# Altium schematic sheet TopSheet.SchDoc
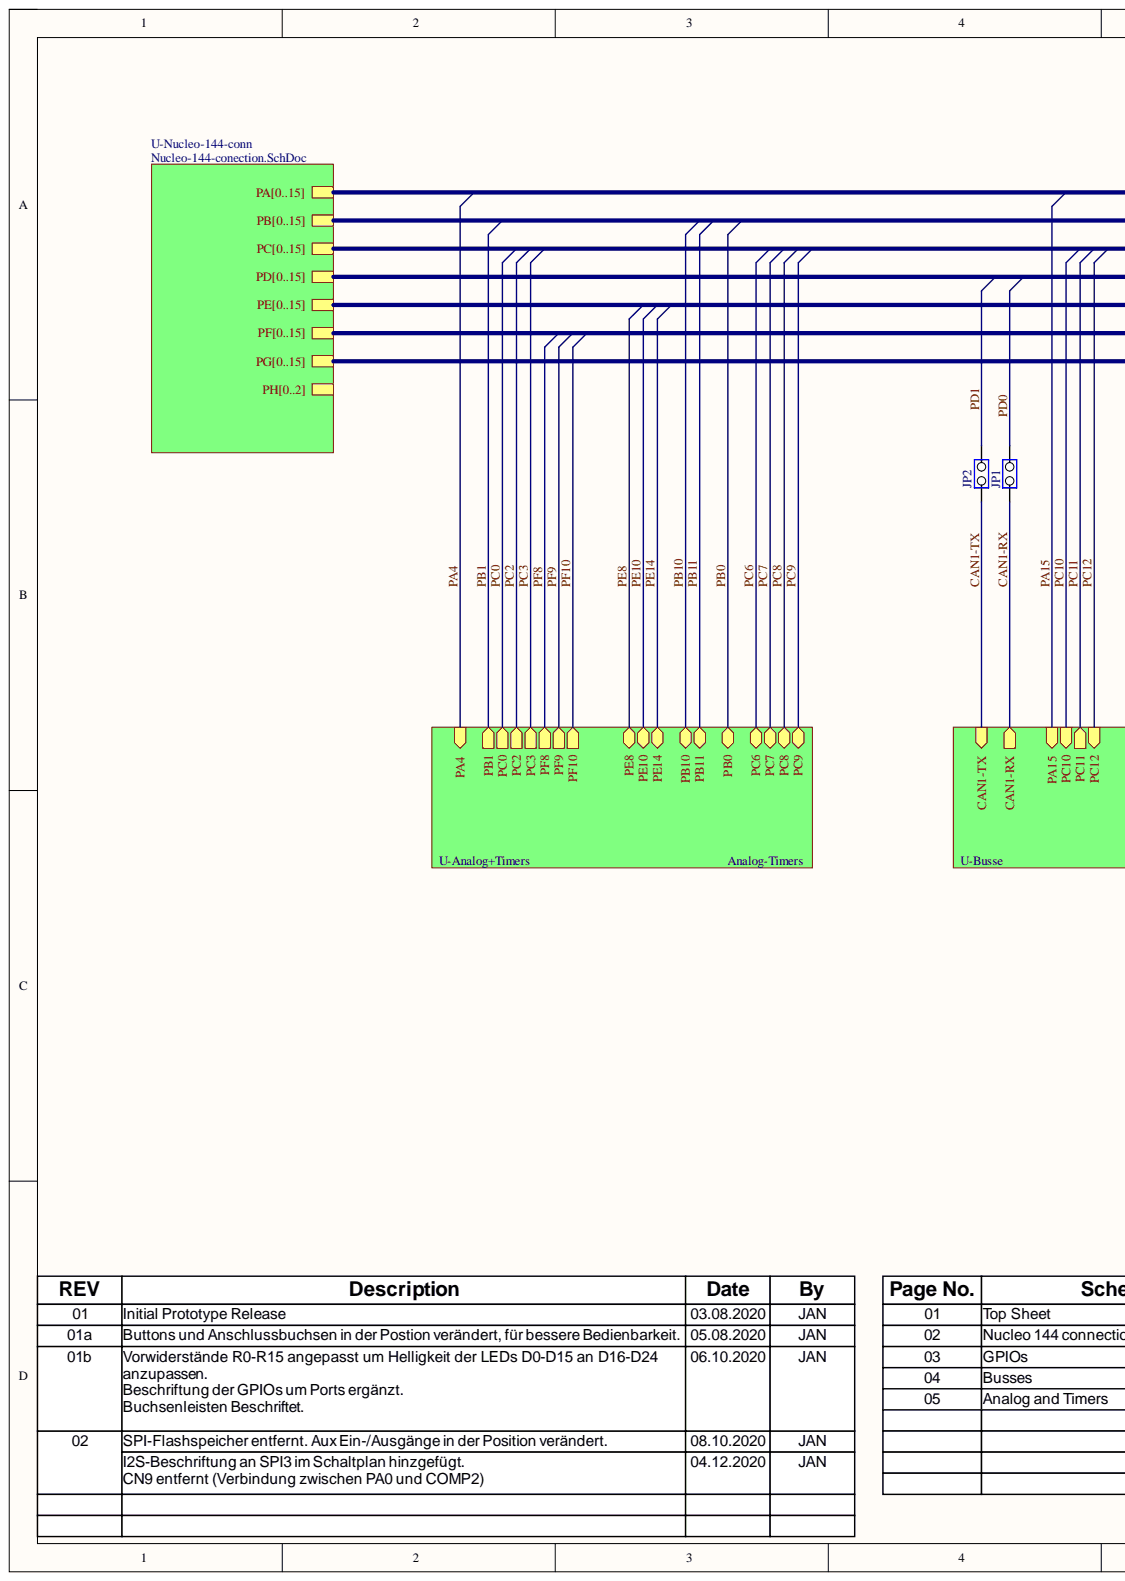
[diagram p0: TopSheet.SchDoc, left]
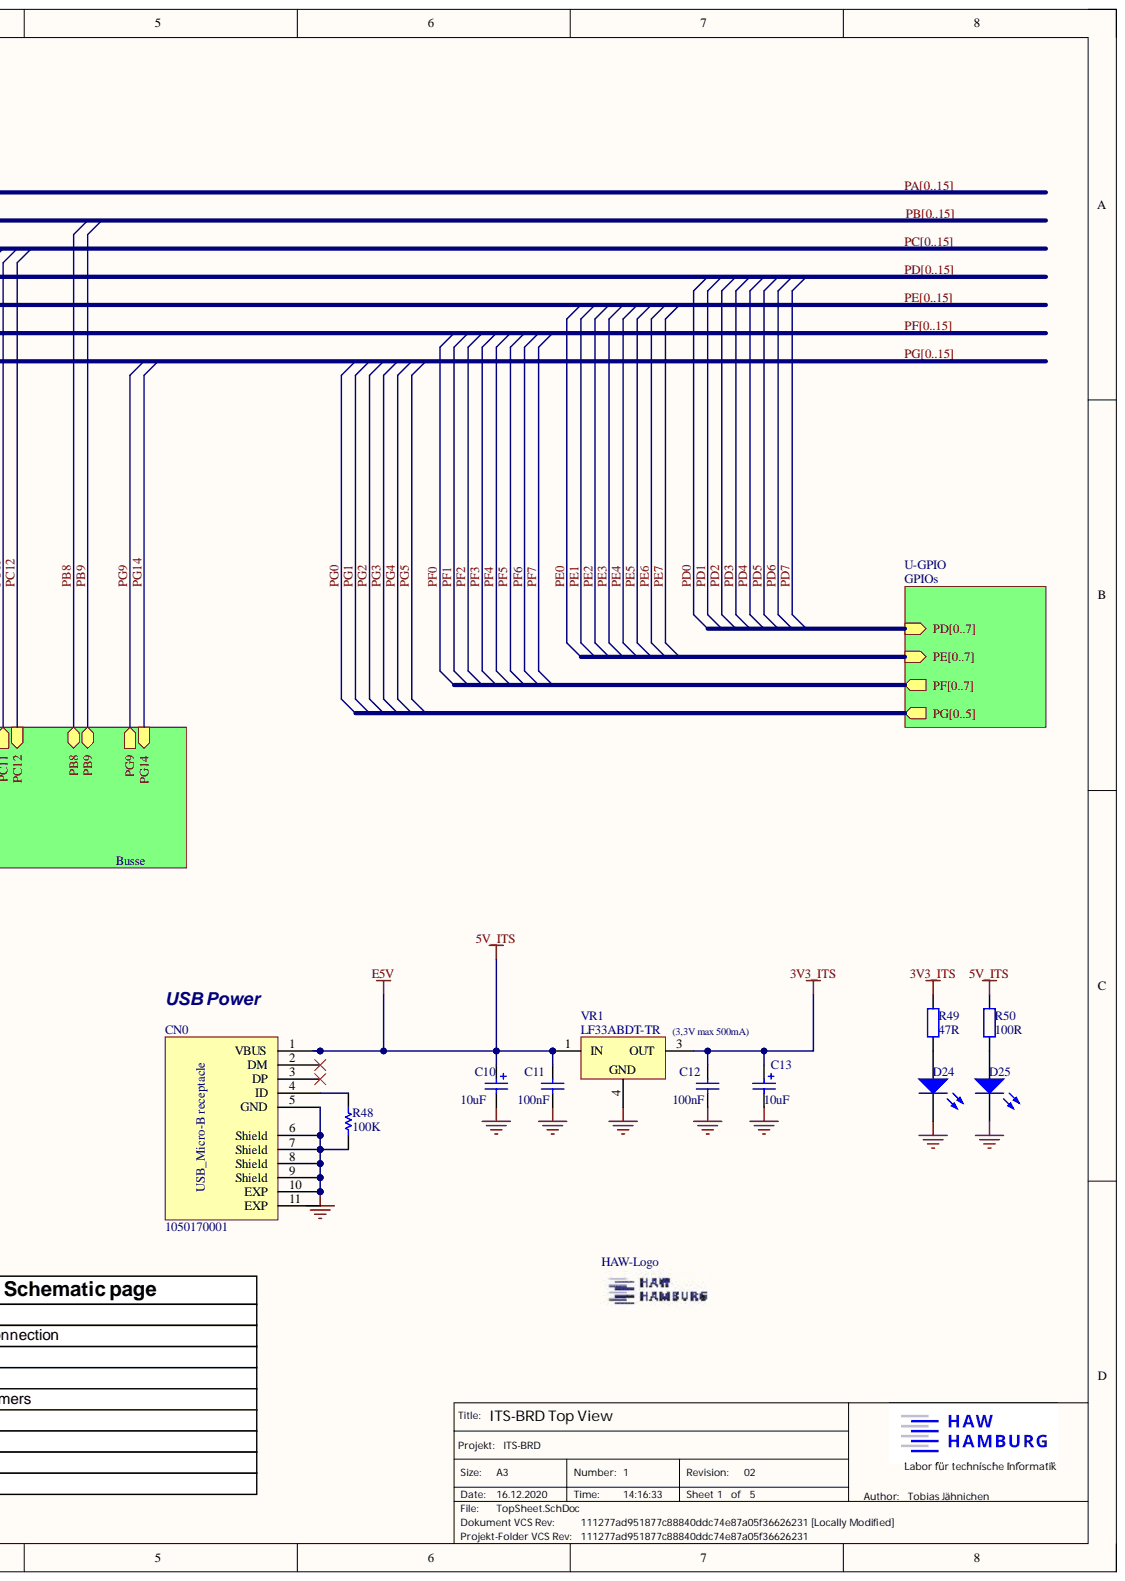
[diagram p1: TopSheet.SchDoc, right]

## Parts
C10  value 10uF  Capacitance: 10uF  [FP-Tantal Cap]  (hidden comment: Cap10uF)
C11  value 100nF  Capacitance: 100nF  [6-0805_M]  (hidden comment: Cap100)
C12  value 100nF  Capacitance: 100nF  [6-0805_M]  (hidden comment: Cap100)
C13  value 10uF  Capacitance: 10uF  [FP-Tantal Cap]  (hidden comment: Cap10uF)
CN0  1050170001  Silkscreen: USB  [Molex_1050170001]
D24  3V3  [VLMY]  (value not drawn)
D25  5V  [VLMY]  (value not drawn)
JP1  JP2  [HDR_1x2_2R54_V_M_S]
JP2  JP2  [HDR_1x2_2R54_V_M_S]
R48  100K  [6-0805_M]
R49  value 47R  Resistance: 47R  [6-0805_M]  (hidden comment: Res47)
R50  value 100R  Resistance: 100R  [6-0805_M]  (hidden comment: Res100)
VR1  LF33ABDT-TR  [TO-252_M]

## Nets
3V3_ITS: C12.2 C13.1 R49.1 VR1.3
5V_ITS: C10.1 C11.2 CN0.1(VBUS) R50.1 VR1.1
GND: C10.2 C11.1 C12.1 C13.2 CN0.5(GND) CN0.6(Shield) CN0.7(Shield) CN0.8(Shield) CN0.9(Shield) CN0.10(EXP) D24.2 D25.2 R48.2 VR1.4
CAN1-RX: JP1.2
CAN1-TX: JP2.2
PD0: JP1.1  [also labelled PD]
PD0: JP1.1  [also labelled PD]
PD1: JP2.1  [also labelled PD]
PD1: JP2.1  [also labelled PD]
NetCN0_2: CN0.2(DM)
NetCN0_3: CN0.3(DP)
NetCN0_4: CN0.4(ID) R48.1
NetCN0_11: CN0.11(EXP)
NetD24_1: D24.1 R49.2
NetD25_1: D25.1 R50.2
PA0:  [also labelled PA]
PA1:  [also labelled PA]
PA2:  [also labelled PA]
PA3:  [also labelled PA]
PA4:  [also labelled PA]
PA5:  [also labelled PA]
PA6:  [also labelled PA]
PA7:  [also labelled PA]
PA8:  [also labelled PA]
PA9:  [also labelled PA]
PA10:  [also labelled PA]
PA11:  [also labelled PA]
PA12:  [also labelled PA]
PA13:  [also labelled PA]
PA14:  [also labelled PA]
PA15:  [also labelled PA]
PB0:  [also labelled PB]
PB1:  [also labelled PB]
PB2:  [also labelled PB]
PB3:  [also labelled PB]
PB4:  [also labelled PB]
PB5:  [also labelled PB]
PB6:  [also labelled PB]
PB7:  [also labelled PB]
PB8:  [also labelled PB]
PB9:  [also labelled PB]
PB10:  [also labelled PB]
PB11:  [also labelled PB]
PB12:  [also labelled PB]
PB13:  [also labelled PB]
PB14:  [also labelled PB]
PB15:  [also labelled PB]
PC0:  [also labelled PC]
PC1:  [also labelled PC]
PC2:  [also labelled PC]
PC3:  [also labelled PC]
PC4:  [also labelled PC]
PC5:  [also labelled PC]
PC6:  [also labelled PC]
PC7:  [also labelled PC]
PC8:  [also labelled PC]
PC9:  [also labelled PC]
PC10:  [also labelled PC]
PC11:  [also labelled PC]
PC12:  [also labelled PC]
PC13:  [also labelled PC]
PC14:  [also labelled PC]
PC15:  [also labelled PC]
PD2:  [also labelled PD]
PD3:  [also labelled PD]
PD4:  [also labelled PD]
PD5:  [also labelled PD]
PD6:  [also labelled PD]
PD7:  [also labelled PD]
PD8:  [also labelled PD]
PD9:  [also labelled PD]
PD10:  [also labelled PD]
PD11:  [also labelled PD]
PD12:  [also labelled PD]
PD13:  [also labelled PD]
PD14:  [also labelled PD]
PD15:  [also labelled PD]
PE0:  [also labelled PE]
PE1:  [also labelled PE]
PE2:  [also labelled PE]
PE3:  [also labelled PE]
PE4:  [also labelled PE]
PE5:  [also labelled PE]
PE6:  [also labelled PE]
PE7:  [also labelled PE]
PE8:  [also labelled PE]
PE9:  [also labelled PE]
PE10:  [also labelled PE]
PE11:  [also labelled PE]
PE12:  [also labelled PE]
PE13:  [also labelled PE]
PE14:  [also labelled PE]
PE15:  [also labelled PE]
PF0:  [also labelled PF]
PF1:  [also labelled PF]
PF2:  [also labelled PF]
PF3:  [also labelled PF]
PF4:  [also labelled PF]
PF5:  [also labelled PF]
PF6:  [also labelled PF]
PF7:  [also labelled PF]
PF8:  [also labelled PF]
PF9:  [also labelled PF]
PF10:  [also labelled PF]
PF11:  [also labelled PF]
PF12:  [also labelled PF]
PF13:  [also labelled PF]
PF14:  [also labelled PF]
PF15:  [also labelled PF]
PG0:  [also labelled PG]
PG1:  [also labelled PG]
PG2:  [also labelled PG]
PG3:  [also labelled PG]
PG4:  [also labelled PG]
PG5:  [also labelled PG]
PG6:  [also labelled PG]
PG7:  [also labelled PG]
PG8:  [also labelled PG]
PG9:  [also labelled PG]
PG10:  [also labelled PG]
PG11:  [also labelled PG]
PG12:  [also labelled PG]
PG13:  [also labelled PG]
PG14:  [also labelled PG]
PG15:  [also labelled PG]
PH[0..2]:

## Library data of the parts
C10 C13 = Cap Pol2: KEMET - T491C106K025AT - Surface Mount Tantalum Capacitor, 10 µF, 25 V, 2312 [6032 Metric], T491 Series, ± 10%, -55 °C; Dissipation Factor: 6%; ESR (Equivalent Series Resistance): 1.5R; Leakage Current: 6uA; Life (Hours): 2000Hour; Polarity: Polar; Tolerance: 20%; Vendor: Farnell: 197634; Voltage: 25V; Case Code (Imperial): 2412; Case Code (Metric): 6032; Case/Package: Molded; Category: Tantalum Capacitors; Component Kind: Standard; ComponentLink1Description: https://datasheet.ciiva.com/23876/1732750-23876722.pdf?src-supplier=Newark; ComponentLink1URL: https://datasheet.ciiva.com/23876/1732750-23876722.pdf?src-supplier=Newark; ComponentLink2Description: https://datasheet.ciiva.com/11793/0900766b80718482-11793044.pdf?src-supplier=RSComponents; ComponentLink2URL: https://datasheet.ciiva.com/11793/0900766b80718482-11793044.pdf?src-supplier=RSComponents; ComponentLink3Description: https://datasheet.ciiva.com/258/kem-t2005-t491-258374.pdf?src-supplier=Digi-Key; ComponentLink3URL: https://datasheet.ciiva.com/258/kem-t2005-t491-258374.pdf?src-supplier=Digi-Key; ComponentLink4Description: https://datasheet.ciiva.com/20171/458351-da-en-01-20171926.pdf?src-supplier=Rapid+Electronics; ComponentLink4URL: https://datasheet.ciiva.com/20171/458351-da-en-01-20171926.pdf?src-supplier=Rapid+Electronics; ComponentLink5Description: https://datasheet.ciiva.com/34302/1573804-34302524.pdf?src-supplier=Jameco; ComponentLink5URL: https://datasheet.ciiva.com/34302/1573804-34302524.pdf?src-supplier=Jameco; ComponentLink6Description: https://datasheet.ciiva.com/26341/458351-da-01-en-kondensator-10uf-6032-10pr-25v-85c-26341615.pdf?src-supplier=Conrad; ComponentLink6URL: https://datasheet.ciiva.com/26341/458351-da-01-en-kondensator-10uf-6032-10pr-25v-85c-26341615.pdf?src-supplier=Conrad; ComponentLink7Description: https://datasheet.ciiva.com/258/kem-t2005-t491-258375.pdf?src-supplier=Digi-Key; ComponentLink7URL: https://datasheet.ciiva.com/258/kem-t2005-t491-258375.pdf?src-supplier=Digi-Key; ComponentLink8Description: https://datasheet.ciiva.com/258/kem-t2005-t491-258376.pdf?src-supplier=Digi-Key; ComponentLink8URL: https://datasheet.ciiva.com/258/kem-t2005-t491-258376.pdf?src-supplier=Digi-Key; ComponentLink9Description: https://datasheet.ciiva.com/14593/70095774-14593130.pdf?src-supplier=Allied+Electronics; ComponentLink9URL: https://datasheet.ciiva.com/14593/70095774-14593130.pdf?src-supplier=Allied+Electronics; ComponentLink10Description: https://datasheet.ciiva.com/7758/1732750-7758925.pdf?src-supplier=Element14; ComponentLink10URL: https://datasheet.ciiva.com/7758/1732750-7758925.pdf?src-supplier=Element14; ComponentLink11Description: https://datasheet.ciiva.com/7758/1698764-7758926.pdf?src-supplier=Element14; ComponentLink11URL: https://datasheet.ciiva.com/7758/1698764-7758926.pdf?src-supplier=Element14; ComponentLink12Description: https://datasheet.ciiva.com/41657/1854511-41657326.pdf?src-supplier=Farnell; ComponentLink12URL: https://datasheet.ciiva.com/41657/1854511-41657326.pdf?src-supplier=Farnell; ComponentLink13Description: https://datasheet.ciiva.com/7758/1732750-7758927.pdf?src-supplier=Element14; ComponentLink13URL: https://datasheet.ciiva.com/7758/1732750-7758927.pdf?src-supplier=Element14; Depth: 3.2mm; Dielectric Material: Tantalum; Features: General Purpose; Height: 2.5mm; Height - Seated (Max): 0.11inch; LatestRevisionDate: 17-Jul-2002; LatestRevisionNote: Re-released for DXP Platform.; Lead Free: Lead Free; Length: 6mm; Library Name: Miscellaneous Devices.IntLib; Manufacturer 1: KEMET; Manufacturer 2: KEMET; Manufacturer 3: KEMET; Manufacturer 4: KEMET; Manufacturer Part Number 1: T491C106K025AT; Manufacturer Part Number 2: T491C106K025AT; Manufacturer Part Number 3: T491C106K025AT; Manufacturer Part Number 4: T491C106K025AT; Max Operating Temperature: 125°C; Min Operating Temperature: -55°C; Mount: Surface Mount; Number of Pins: 2; Package Quantity: 1; PackageReference: POLAR0.8; Packaging: Tape and Reel; Pricing 3: 1=0,65000 (EUR); Published: 8-Jun-2000; Radiation Hardening: No; REACH SVHC: No SVHC; RoHS: Yes; Signal Integrity: Cap Pol; Simulation: CAP; Stock 1: 25146; Stock 2: 5480; Stock 4: 26000; Supplier 1: Mouser; Supplier 2: RSComponents; Supplier 3: Conrad; Supplier 4: Farnell; Supplier Part Number 1: 80-T491C106K025; Supplier Part Number 2: 5382470; Supplier Part Number 3: 458351; Supplier Part Number 4: 2250141; Termination: SMD/SMT; Voltage Rating: 25V; Voltage Rating (DC): 25V; Width: 3.2mm; Publisher: Altium Limited
C11 C12 = Cap: AVX - 08055C104K4Z2A. - CERAMIC CAPACITOR, 0.1UF, 50V, X7R, 10%, 0805, FULL REEL; Manufacturer 1: Kyocera AVX; Temperature Coefficient: 30ppm/°C; Vendor: Farnell: 1301717; Case Code (Imperial): 0805; Case Code (Metric): 2012; Case/Package: 0805; Category: Ceramic Capacitors; Component Kind: Standard; ComponentLink1Description: https://datasheet.ciiva.com/11786/0900766b80dc2409-11786582.pdf?src-supplier=RSComponents; ComponentLink1URL: https://datasheet.ciiva.com/11786/0900766b80dc2409-11786582.pdf?src-supplier=RSComponents; ComponentLink2Description: https://datasheet.ciiva.com/151/flexiterm-151542.pdf?src-supplier=Digi-Key; ComponentLink2URL: https://datasheet.ciiva.com/151/flexiterm-151542.pdf?src-supplier=Digi-Key; ComponentLink3Description: https://datasheet.ciiva.com/28613/630895-28613350.pdf?src-supplier=Element14; ComponentLink3URL: https://datasheet.ciiva.com/28613/630895-28613350.pdf?src-supplier=Element14; ComponentLink4Description: https://datasheet.ciiva.com/151/flexiterm-151543.pdf?src-supplier=Digi-Key; ComponentLink4URL: https://datasheet.ciiva.com/151/flexiterm-151543.pdf?src-supplier=Digi-Key; ComponentLink5Description: https://datasheet.ciiva.com/151/flexiterm-151544.pdf?src-supplier=Digi-Key; ComponentLink5URL: https://datasheet.ciiva.com/151/flexiterm-151544.pdf?src-supplier=Digi-Key; ComponentLink6Description: https://datasheet.ciiva.com/16326/cauto-16326441.pdf?src-supplier=Future+Electronics; ComponentLink6URL: https://datasheet.ciiva.com/16326/cauto-16326441.pdf?src-supplier=Future+Electronics; ComponentLink7Description: https://datasheet.ciiva.com/11504/flexiterm-11504268.pdf?src-supplier=Avnet; ComponentLink7URL: https://datasheet.ciiva.com/11504/flexiterm-11504268.pdf?src-supplier=Avnet; ComponentLink8Description: https://datasheet.ciiva.com/7812/flexiterm-7812077.pdf?src-supplier=Element14; ComponentLink8URL: https://datasheet.ciiva.com/7812/flexiterm-7812077.pdf?src-supplier=Element14; ComponentLink9Description: https://datasheet.ciiva.com/7812/flexiterm-7812078.pdf?src-supplier=Element14; ComponentLink9URL: https://datasheet.ciiva.com/7812/flexiterm-7812078.pdf?src-supplier=Element14; ComponentLink10Description: https://datasheet.ciiva.com/28613/630895-28613343.pdf?src-supplier=Element14; ComponentLink10URL: https://datasheet.ciiva.com/28613/630895-28613343.pdf?src-supplier=Element14; Contact Plating: Tin,Matte; Depth: 1.25mm; Dielectric: X7R; Dielectric Material: Ceramic; Features: Soft Termination; Height: 0.94mm; LatestRevisionDate: 17-Jul-2002; LatestRevisionNote: Re-released for DXP Platform.; Lead Free: Lead Free; Length: 2.01mm; Library Name: Miscellaneous Devices.IntLib; Manufacturer 2: Kyocera AVX; Manufacturer 3: Kyocera AVX; Manufacturer Part Number 1: 08055C104K4Z2A; Manufacturer Part Number 2: 08055C104K4Z2A; Manufacturer Part Number 3: 08055C104K4Z2A; Max Operating Temperature: 125°C; Min Operating Temperature: -55°C; Mount: Surface Mount; Number of Pins: 2; Package Quantity: 1; PackageReference: RAD-0.3; Packaging: Tape and Reel; Published: 8-Jun-2000; Radiation Hardening: No; Ratings: AEC-Q200; REACH SVHC: No SVHC; RoHS: Yes; Signal Integrity: Cap; Simulation: CAP; Stock 1: 29500; Stock 2: 139593; Stock 3: 128000; Supplier 1: RSComponents; Supplier 2: Mouser; Supplier 3: Farnell; Supplier Part Number 1: 1353231; Supplier Part Number 2: 581-08055C104K4Z2A; Supplier Part Number 3: 2665280; Termination: SMD/SMT; Thickness: 0.037inch; Tolerance: 10%; Voltage: 50V; Voltage Rating: 50V; Voltage Rating (DC): 50V; Width: 1.25mm; Publisher: Altium Limited
CN0 = 1050170001: Manufacturer 1: Molex; Manufacturer Part Number 1: 105017-0001; Component Kind: Standard; Mount: Mixed; Supplier 1: RSComponents; Supplier 2: Mouser; Supplier 3: RSComponents; Supplier 4: Farnell; Supplier 5: Farnell; Supplier 6: Farnell; Supplier 7: Farnell; Supplier 8: Farnell; Supplier Part Number 1: 8486764; Supplier Part Number 2: 538-105017-0001; Supplier Part Number 3: 8486764P; Supplier Part Number 4: 2293836; Supplier Part Number 5: 3500663; Supplier Part Number 6: 3499359; Supplier Part Number 7: 2411265; Supplier Part Number 8: 1847433; Library Name: Smartphone_accessory.SCHLIB
D24 D25 = LED1: SMD-LED YELLOW PLCC2-e3; Manufacturer 1: Vishay Semiconductors; Manufacturer Part Number 1: VLMY3102-GS08; Dominant Wavelength: 588nm; Forward Current: 30mA; Forward Voltage: 2.1V; Lens Style: Diffused,Circular; Lens Transparency: Clear; Luminous Intensity: 18mcd; Number of Elements: 1; Power Dissipation: 100mW; Reverse Voltage: 15V; Test Current: 10mA; Vendor: Farnell: 1226374; Viewing Angle: 120°; Case/Package: PLCC; Category: LEDs; Color: Yellow; Component Kind: Standard; ComponentLink1Description: https://datasheet.ciiva.com/2115/vlmg310-2115535.pdf?src-supplier=Digi-Key; ComponentLink1URL: https://datasheet.ciiva.com/2115/vlmg310-2115535.pdf?src-supplier=Digi-Key; ComponentLink2Description: https://datasheet.ciiva.com/2115/vlmg310-2115536.pdf?src-supplier=Digi-Key; ComponentLink2URL: https://datasheet.ciiva.com/2115/vlmg310-2115536.pdf?src-supplier=Digi-Key; ComponentLink3Description: https://datasheet.ciiva.com/2115/vlmg310-2115537.pdf?src-supplier=Digi-Key; ComponentLink3URL: https://datasheet.ciiva.com/2115/vlmg310-2115537.pdf?src-supplier=Digi-Key; ComponentLink4Description: https://datasheet.ciiva.com/26219/vlmg310-26219352.pdf?src-supplier=Avnet+Europe; ComponentLink4URL: https://datasheet.ciiva.com/26219/vlmg310-26219352.pdf?src-supplier=Avnet+Europe; Depth: 2.8mm; Height: 1.85mm; LatestRevisionDate: 08-Jul-2005; LatestRevisionNote: Mech Layer 1 removed.; Length: 3mm; Library Name: Miscellaneous Devices.IntLib; Max Operating Temperature: 100°C; Min Operating Temperature: -40°C; Mount: Surface Mount; Number of LEDs: 1; Number of Pins: 2; Package Quantity: 1; PackageReference: LED-1; Packaging: Tape and Reel; Published: 8-Jun-2000; Radiation Hardening: No; RoHS: Yes; Simulation: LED1; Stock 1: 4690; Supplier 1: Mouser; Supplier Part Number 1: 78-VLMY3102-GS08; Width: 2.8mm; Publisher: Altium Limited
JP1 JP2 = JP2: SAMTEC - TSW-102-07-G-S - Board-To-Board Connector, Unshrouded, TSW Series, Through Hole, Header, 2, 2.54 mm RoHS Compliant: Yes; Connector Type: Male Pin; Gender: Male; Max Current Rating: 7.6A; Max Voltage Rating (AC): 550V; Number of Contacts: 2; Pitch: 2.54mm; Category: Headers and Wire Housings; Color: Black; Component Kind: Standard; ComponentLink1Description: https://datasheet.ciiva.com/16734/htsw-th-16734220.pdf?src-supplier=Avnet+Europe; ComponentLink1URL: https://datasheet.ciiva.com/16734/htsw-th-16734220.pdf?src-supplier=Avnet+Europe; ComponentLink2Description: https://datasheet.ciiva.com/6889/tsw-xxx-xx-xxx-x-xx-xxx-mkt-6889981.pdf?src-supplier=Digi-Key; ComponentLink2URL: https://datasheet.ciiva.com/6889/tsw-xxx-xx-xxx-x-xx-xxx-mkt-6889981.pdf?src-supplier=Digi-Key; Contact Material: Bronze; Contact Mating Length: 0.23inch; Contact Plating: Gold; Depth: 2.54mm; Housing Color: Black; Housing Material: Polyester; Lead Free: Lead Free; Length: 5.08mm; Library Name: MB1137.SCHLIB; Manufacturer 1: Samtec; Manufacturer 2: Samtec; Manufacturer 3: Samtec; Manufacturer Part Number 1: TSW-102-07-G-S; Manufacturer Part Number 2: TSW-102-07-G-S; Manufacturer Part Number 3: TSW-102-07-G-S; Max Operating Temperature: 125°C; Min Operating Temperature: -55°C; Mount: Through Hole; Number of Positions: 2; Number of Rows: 1; Orientation: Straight; Package Quantity: 1; Packaging: Bulk; REACH SVHC: No SVHC; RoHS: Yes; Stock 1: 64646; Stock 2: 300; Stock 3: 920; Supplier 1: Mouser; Supplier 2: RSComponents; Supplier 3: Farnell; Supplier Part Number 1: 200-TSW10207GS; Supplier Part Number 2: 1557981; Supplier Part Number 3: 2505044; Termination: Solder
  JP1: Manufacturer: Samtec; Manufacturer Part Number: TSW-102-07-G-S
R48 = Resistor_ST: Res Thick Film 0805 100K Ohm 1% 0.125W(1/8W) +/-100ppm/ßC Pad SMD Automotive T/R; Manufacturer 1: Vishay; Manufacturer Part Number 1: CRCW0805100KFKEA; Power Rating: 125mW; Resistance: 100kR; Temperature Coefficient: 100ppm/°C; Value: 10K; Voltage Rating: 150V; Case Code (Imperial): 0805; Case Code (Metric): 2012; Case/Package: 0805; Category: Chip SMD Resistors; Component Kind: Standard; ComponentLink1Description: https://datasheet.ciiva.com/11809/0900766b80d98b26-11809057.pdf?src-supplier=RSComponents; ComponentLink1URL: https://datasheet.ciiva.com/11809/0900766b80d98b26-11809057.pdf?src-supplier=RSComponents; ComponentLink2Description: https://datasheet.ciiva.com/7777/1697337-7777349.pdf?src-supplier=Element14; ComponentLink2URL: https://datasheet.ciiva.com/7777/1697337-7777349.pdf?src-supplier=Element14; ComponentLink3Description: https://datasheet.ciiva.com/3258/dcrcwe3-3258006.pdf?src-supplier=Avnet; ComponentLink3URL: https://datasheet.ciiva.com/3258/dcrcwe3-3258006.pdf?src-supplier=Avnet; ComponentLink4Description: https://datasheet.ciiva.com/16754/70201119-16754822.pdf?src-supplier=Allied+Electronics; ComponentLink4URL: https://datasheet.ciiva.com/16754/70201119-16754822.pdf?src-supplier=Allied+Electronics; ComponentLink5Description: https://datasheet.ciiva.com/8337/dcrcwe3-8337738.pdf?src-supplier=Avnet; ComponentLink5URL: https://datasheet.ciiva.com/8337/dcrcwe3-8337738.pdf?src-supplier=Avnet; ComponentLink6Description: https://datasheet.ciiva.com/16341/dcrcwe3-16341530.pdf?src-supplier=Future+Electronics; ComponentLink6URL: https://datasheet.ciiva.com/16341/dcrcwe3-16341530.pdf?src-supplier=Future+Electronics; ComponentLink7Description: https://datasheet.ciiva.com/16852/dcrcwe3-16852810.pdf?src-supplier=Avnet+Europe; ComponentLink7URL: https://datasheet.ciiva.com/16852/dcrcwe3-16852810.pdf?src-supplier=Avnet+Europe; ComponentLink8Description: https://datasheet.ciiva.com/16720/70201119-16720891.pdf?src-supplier=Allied+Electronics; ComponentLink8URL: https://datasheet.ciiva.com/16720/70201119-16720891.pdf?src-supplier=Allied+Electronics; ComponentLink9Description: https://datasheet.ciiva.com/7777/1720486-7777565.pdf?src-supplier=Element14; ComponentLink9URL: https://datasheet.ciiva.com/7777/1720486-7777565.pdf?src-supplier=Element14; Composition: Thick Film; Contact Plating: Tin; Depth: 1.25mm; Height: 0.45mm; Lead Free: Lead Free; Length: 2mm; Library Name: Miscellaneous Devices.IntLib; Manufacturer 2: Vishay; Manufacturer 3: Vishay; Manufacturer Part Number 2: CRCW0805100KFKEA; Manufacturer Part Number 3: CRCW0805100KFKEA; Max Operating Temperature: 155°C; Military Standard: Not; Min Operating Temperature: -55°C; Mount: Surface Mount; Number of Pins: 2; Package Quantity: 5000; Packaging: Tape and Reel; Radiation Hardening: No; REACH SVHC: Unknown; RoHS: Yes; Stock 1: 7950; Stock 2: 178182; Stock 3: 168965; Supplier 1: RSComponents; Supplier 2: Mouser; Supplier 3: Farnell; Supplier Part Number 1: 6790803; Supplier Part Number 2: 71-CRCW0805-100K-E3; Supplier Part Number 3: 1469860; Termination: SMD/SMT; Tolerance: 1%; Voltage Rating (DC): 150V; Weight: 0.000194oz; Width: 1.25mm
R49 = Res2: VISHAY - CRCW080547R0FKEA - Surface Mount Thick Film Resistor, AEC-Q200 CRCW Series, 47 ohm, 125 mW, - 1%, 150 V RoHS Compliant: Yes; Max Power Dissipation: 125mW; Temperature Coefficient: 100ppm/°C; Vendor: Farnell: 9332804; Voltage Rating: 150V; Case Code (Imperial): 0805; Case Code (Metric): 2012; Case/Package: 0805; Category: Chip SMD Resistors; Component Kind: Standard; ComponentLink1Description: https://datasheet.ciiva.com/11810/0900766b80d98b26-11810031.pdf?src-supplier=RSComponents; ComponentLink1URL: https://datasheet.ciiva.com/11810/0900766b80d98b26-11810031.pdf?src-supplier=RSComponents; ComponentLink2Description: https://datasheet.ciiva.com/5544/dcrcwe3-109170-5544135.pdf?src-supplier=Mouser; ComponentLink2URL: https://datasheet.ciiva.com/5544/dcrcwe3-109170-5544135.pdf?src-supplier=Mouser; ComponentLink3Description: https://datasheet.ciiva.com/2525/dcrcwe3-2525962.pdf?src-supplier=Digi-Key; ComponentLink3URL: https://datasheet.ciiva.com/2525/dcrcwe3-2525962.pdf?src-supplier=Digi-Key; ComponentLink4Description: https://datasheet.ciiva.com/7777/1533075-7777578.pdf?src-supplier=Element14; ComponentLink4URL: https://datasheet.ciiva.com/7777/1533075-7777578.pdf?src-supplier=Element14; ComponentLink5Description: https://datasheet.ciiva.com/15225/getdatasheetpartid-251075-15225323.pdf?src-supplier=Verical; ComponentLink5URL: https://datasheet.ciiva.com/15225/getdatasheetpartid-251075-15225323.pdf?src-supplier=Verical; ComponentLink6Description: https://datasheet.ciiva.com/16514/dcrcwe3-16514683.pdf?src-supplier=Avnet+Asia; ComponentLink6URL: https://datasheet.ciiva.com/16514/dcrcwe3-16514683.pdf?src-supplier=Avnet+Asia; ComponentLink7Description: https://datasheet.ciiva.com/17178/dcrcwe3-17178093.pdf?src-supplier=Avnet+Europe; ComponentLink7URL: https://datasheet.ciiva.com/17178/dcrcwe3-17178093.pdf?src-supplier=Avnet+Europe; ComponentLink8Description: https://datasheet.ciiva.com/9005/dcrcwe3-9005486.pdf?src-supplier=Avnet; ComponentLink8URL: https://datasheet.ciiva.com/9005/dcrcwe3-9005486.pdf?src-supplier=Avnet; ComponentLink9Description: https://datasheet.ciiva.com/16200/dcrcwe3-16200127.pdf?src-supplier=Future+Electronics; ComponentLink9URL: https://datasheet.ciiva.com/16200/dcrcwe3-16200127.pdf?src-supplier=Future+Electronics; Composition: Thick Film; Contact Plating: Tin; Depth: 1.25mm; Features: Automotive AEC-Q200; Height: 0.45mm; LatestRevisionDate: 17-Jul-2002; LatestRevisionNote: Re-released for DXP Platform.; Lead Free: Lead Free; Length: 2mm; Library Name: Miscellaneous Devices.IntLib; Manufacturer 1: Vishay; Manufacturer 2: Vishay; Manufacturer 3: Vishay; Manufacturer Part Number 1: CRCW080547R0FKEA; Manufacturer Part Number 2: CRCW080547R0FKEA; Manufacturer Part Number 3: CRCW080547R0FKEA; Max Operating Temperature: 155°C; Military Standard: Not; Min Operating Temperature: -55°C; Mount: Surface Mount; Number of Terminations: 2; Package Quantity: 5000; PackageReference: AXIAL-0.4; Packaging: Tape and Reel; Power Rating: 125mW; Published: 8-Jun-2000; Radiation Hardening: No; REACH SVHC: Unknown; RoHS: Yes; Signal Integrity: Res; Simulation: RESISTOR; Stock 1: 7100; Stock 2: 17103; Stock 3: 27982; Supplier 1: RSComponents; Supplier 2: Mouser; Supplier 3: Farnell; Supplier Part Number 1: 6791446; Supplier Part Number 2: 71-CRCW0805-47-E3; Supplier Part Number 3: 1653007; Termination: SMD/SMT; Tolerance: 1%; Voltage Rating (DC): 150V; Weight: 0.000194oz; Width: 1.25mm; Publisher: Altium Limited
R50 = Res2: Res Thick Film 0805 100 Ohm 1% 0.125W(1/8W) ±100ppm/C Molded SMD Automotive Paper T/R; Manufacturer 1: Vishay Dale; Manufacturer Part Number 1: CRCW0805100RFKEA; Max Power Dissipation: 125mW; Temperature Coefficient: 100ppm/°C; Vendor: Farnell: 9333037; Voltage Rating: 150V; Case Code (Imperial): 0805; Case Code (Metric): 2012; Case/Package: 0805; Category: Chip SMD Resistors; Component Kind: Standard; ComponentLink1Description: https://datasheet.ciiva.com/11809/0900766b80d98b26-11809126.pdf?src-supplier=RSComponents; ComponentLink1URL: https://datasheet.ciiva.com/11809/0900766b80d98b26-11809126.pdf?src-supplier=RSComponents; ComponentLink2Description: https://datasheet.ciiva.com/16514/dcrcwe3-16514904.pdf?src-supplier=Avnet+Asia; ComponentLink2URL: https://datasheet.ciiva.com/16514/dcrcwe3-16514904.pdf?src-supplier=Avnet+Asia; ComponentLink3Description: https://datasheet.ciiva.com/8337/dcrcwe3-8337753.pdf?src-supplier=Avnet; ComponentLink3URL: https://datasheet.ciiva.com/8337/dcrcwe3-8337753.pdf?src-supplier=Avnet; ComponentLink4Description: https://datasheet.ciiva.com/16777/70201119-16777129.pdf?src-supplier=Allied+Electronics; ComponentLink4URL: https://datasheet.ciiva.com/16777/70201119-16777129.pdf?src-supplier=Allied+Electronics; ComponentLink5Description: https://datasheet.ciiva.com/3258/dcrcwe3-3258011.pdf?src-supplier=Avnet; ComponentLink5URL: https://datasheet.ciiva.com/3258/dcrcwe3-3258011.pdf?src-supplier=Avnet; ComponentLink6Description: https://datasheet.ciiva.com/5546/dcrcwe3-109170-5546700.pdf?src-supplier=Mouser; ComponentLink6URL: https://datasheet.ciiva.com/5546/dcrcwe3-109170-5546700.pdf?src-supplier=Mouser; ComponentLink7Description: https://datasheet.ciiva.com/16760/70201119-16760940.pdf?src-supplier=Allied+Electronics; ComponentLink7URL: https://datasheet.ciiva.com/16760/70201119-16760940.pdf?src-supplier=Allied+Electronics; ComponentLink8Description: https://datasheet.ciiva.com/2495/dcrcwe3-2495842.pdf?src-supplier=Digi-Key; ComponentLink8URL: https://datasheet.ciiva.com/2495/dcrcwe3-2495842.pdf?src-supplier=Digi-Key; ComponentLink9Description: https://datasheet.ciiva.com/2495/dcrcwe3-2495843.pdf?src-supplier=Digi-Key; ComponentLink9URL: https://datasheet.ciiva.com/2495/dcrcwe3-2495843.pdf?src-supplier=Digi-Key; ComponentLink10Description: https://datasheet.ciiva.com/2495/dcrcwe3-2495844.pdf?src-supplier=Digi-Key; ComponentLink10URL: https://datasheet.ciiva.com/2495/dcrcwe3-2495844.pdf?src-supplier=Digi-Key; ComponentLink11Description: https://datasheet.ciiva.com/15159/getdatasheetpartid-90825-15159895.pdf?src-supplier=Verical; ComponentLink11URL: https://datasheet.ciiva.com/15159/getdatasheetpartid-90825-15159895.pdf?src-supplier=Verical; Composition: Thick Film; Contact Plating: Tin; Depth: 1.25mm; Features: Automotive AEC-Q200; Height: 0.45mm; LatestRevisionDate: 17-Jul-2002; LatestRevisionNote: Re-released for DXP Platform.; Lead Free: Lead Free; Length: 2mm; Library Name: Miscellaneous Devices.IntLib; Manufacturer 2: Vishay Dale; Manufacturer 3: Vishay Dale; Manufacturer Part Number 2: CRCW0805100RFKEA; Manufacturer Part Number 3: CRCW0805100RFKEA; Max Operating Temperature: 155°C; Military Standard: Not; Min Operating Temperature: -55°C; Mount: Surface Mount; Number of Pins: 2; Number of Terminations: 2; Package Quantity: 10; PackageReference: AXIAL-0.4; Packaging: Tape and Reel; Power Rating: 125mW; Published: 8-Jun-2000; Radiation Hardening: No; REACH SVHC: Unknown; RoHS: Yes; Signal Integrity: Res; Simulation: RESISTOR; Stock 1: 324540; Stock 2: 96600; Stock 3: 320000; Supplier 1: Mouser; Supplier 2: RSComponents; Supplier 3: Farnell; Supplier Part Number 1: 71-CRCW0805-100-E3; Supplier Part Number 2: 6790807; Supplier Part Number 3: 2123001; Termination: SMD/SMT; Tolerance: 1%; Voltage Rating (DC): 150V; Weight: 0.000194oz; Width: 0.049inch; Publisher: Altium Limited
VR1 = LF33ABDT-TR: STMICROELECTRONICS - LF33ABDT-TR - Fixed LDO Voltage Regulator, 3V to 16V, 400mV Dropout, 3.3Vout, 0.5Aout, TO-252-3; Accuracy: 1%; Input Bias Current: 500uA; Max Output Current: 500mA; Max Output Voltage: 3.3V; Min Supply Voltage: 4.3V; Number of Outputs: 1; Polarity: Positive; Quiescent Current: 1mA; Case/Package: TO-252; Category: Voltage Regulators - Linear; Component Kind: Standard; ComponentLink1Description: Manufacturer URL; ComponentLink1URL: http://www.st.com; ComponentLink2Description: Datasheet; ComponentLink2URL: http://www.st.com/internet/com/TECHNICAL_RESOURCES/TECHNICAL_LITERATURE/DATASHEET/CD00000546.pdf; ComponentLink3Description: https://datasheet.ciiva.com/1511/1806095-1511654.pdf?src-supplier=Element14; ComponentLink3URL: https://datasheet.ciiva.com/1511/1806095-1511654.pdf?src-supplier=Element14; ComponentLink4Description: https://datasheet.ciiva.com/2043/cd00000546-2043943.pdf?src-supplier=Digi-Key; ComponentLink4URL: https://datasheet.ciiva.com/2043/cd00000546-2043943.pdf?src-supplier=Digi-Key; ComponentLink5Description: https://datasheet.ciiva.com/2043/cd00000546-2043944.pdf?src-supplier=Digi-Key; ComponentLink5URL: https://datasheet.ciiva.com/2043/cd00000546-2043944.pdf?src-supplier=Digi-Key; ComponentLink6Description: https://datasheet.ciiva.com/2043/cd00000546-2043945.pdf?src-supplier=Digi-Key; ComponentLink6URL: https://datasheet.ciiva.com/2043/cd00000546-2043945.pdf?src-supplier=Digi-Key; ComponentLink7Description: https://datasheet.ciiva.com/16392/cd00000546-16392073.pdf?src-supplier=Future+Electronics; ComponentLink7URL: https://datasheet.ciiva.com/16392/cd00000546-16392073.pdf?src-supplier=Future+Electronics; ComponentLink8Description: https://datasheet.ciiva.com/22694/1806095-22694861.pdf?src-supplier=Element14; ComponentLink8URL: https://datasheet.ciiva.com/22694/1806095-22694861.pdf?src-supplier=Element14; ComponentLink9Description: https://datasheet.ciiva.com/8228/cd00000546-8228718.pdf?src-supplier=Avnet; ComponentLink9URL: https://datasheet.ciiva.com/8228/cd00000546-8228718.pdf?src-supplier=Avnet; Contact Plating: Tin,Matte; DatasheetVersion: 1/11/2010; Dropout Voltage: 400mV; Height: 2.4mm; Lead Free: Lead Free; Length: 6.6mm; Library Name: STMicroelectronics_LF33ABDT_TR.SchLib; Manufacturer 1: STMicroelectronics; Manufacturer 2: STMicroelectronics; Manufacturer 3: STMicroelectronics; Manufacturer Part Number 1: LF33ABDT-TR; Manufacturer Part Number 2: LF33ABDT-TR; Manufacturer Part Number 3: LF33ABDT-TR; Max Input Voltage: 16V; Max Operating Temperature: 125°C; Max Supply Voltage: 16V; Min Input Voltage: 3V; Min Operating Temperature: -40°C; Min Output Voltage: 3.3V; Mount: Surface Mount; Nominal Output Voltage: 3.3V; Number of Pins: 3; Number of Regulators: 1; Output Current: 500mA; Output Type: Fixed; Output Voltage: 3.3V; Output Voltage Accuracy: 1%; Package Quantity: 1; PackageDescription: 3-Pin Transistor Outline Package 2.28 mm Pitch, 9.7 mm Lead Span (DPAK); PackageReference: TO-252; PackageVersion: 2011; Packaging: Tape and Reel; PartNumber: LF33ABDT-TR; Radiation Hardening: No; REACH SVHC: No SVHC; RoHS: Yes; Stock 1: 120; Stock 2: 19866; Stock 3: 24352; Supplier 1: RSComponents; Supplier 2: Mouser; Supplier 3: Farnell; Supplier Part Number 1: 6868934; Supplier Part Number 2: 511-LF33ABDT-TR; Supplier Part Number 3: 1087183; Termination: SMD/SMT; Voltage: 3.3V; Width: 6.2mm
